# Altium schematic sheet Sheet1.SchDoc
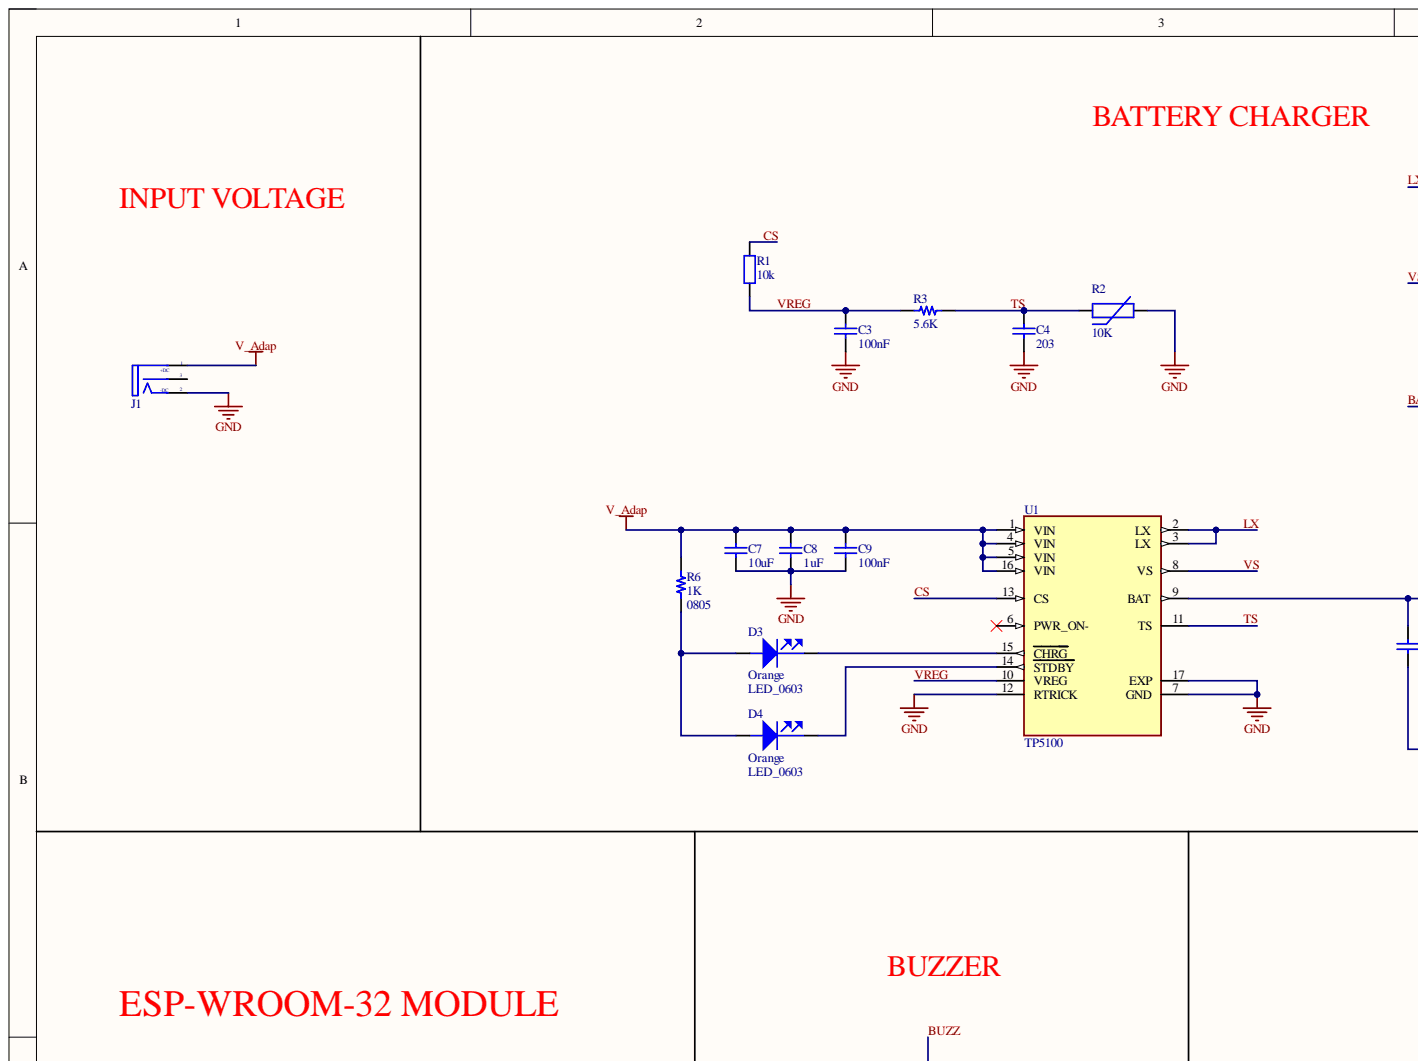
[diagram p0: Sheet1.SchDoc, top left]
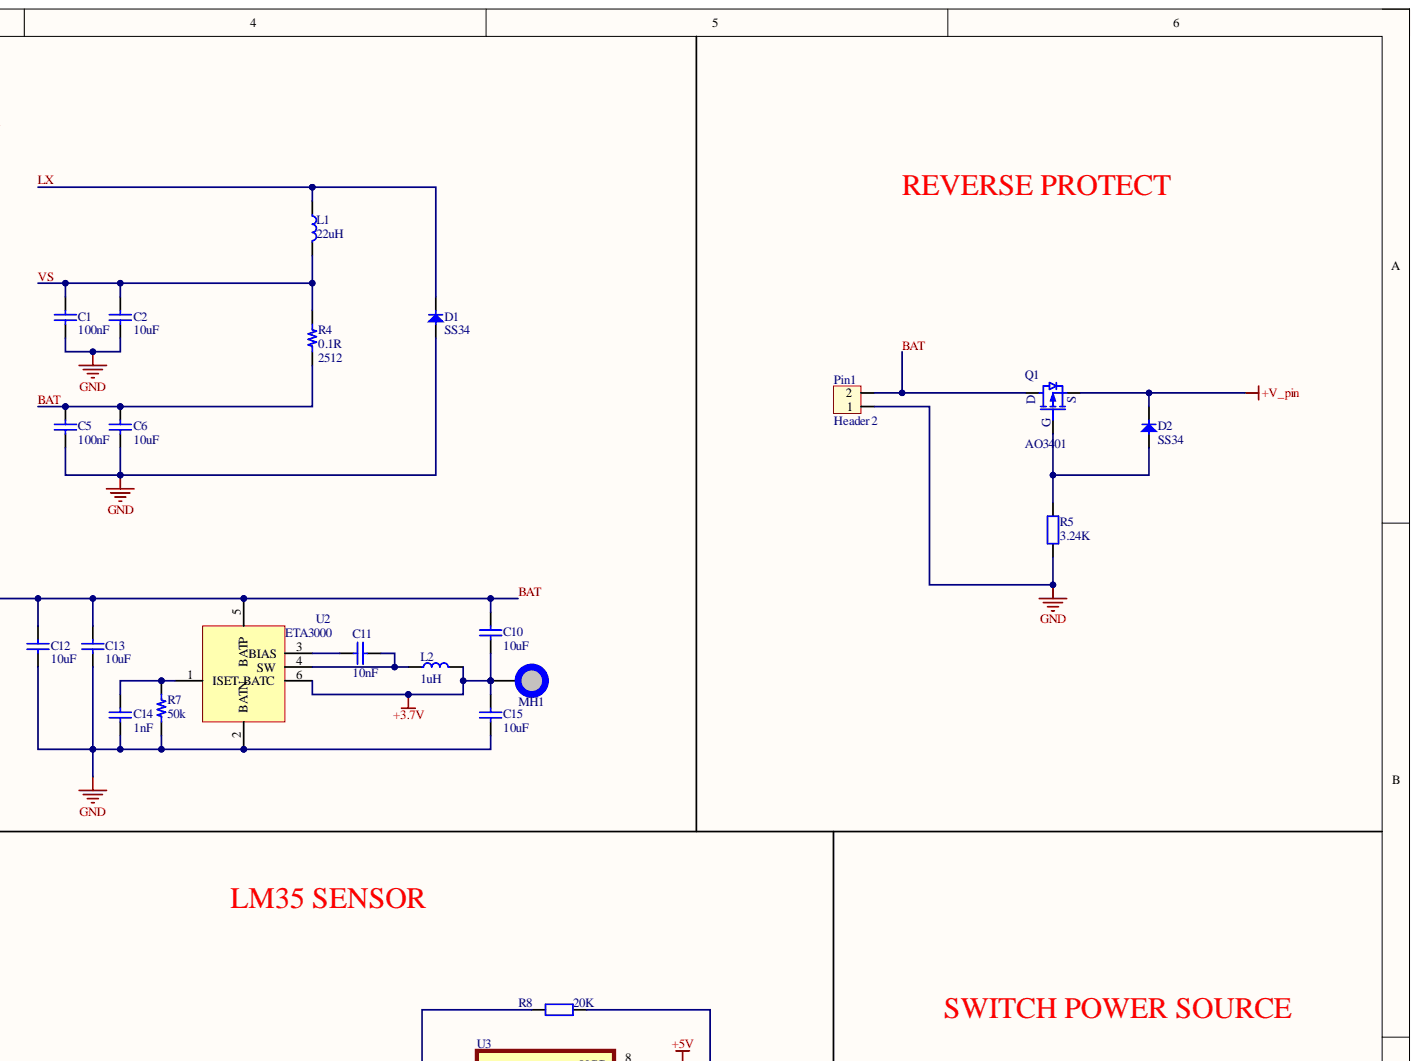
[diagram p1: Sheet1.SchDoc, top right]
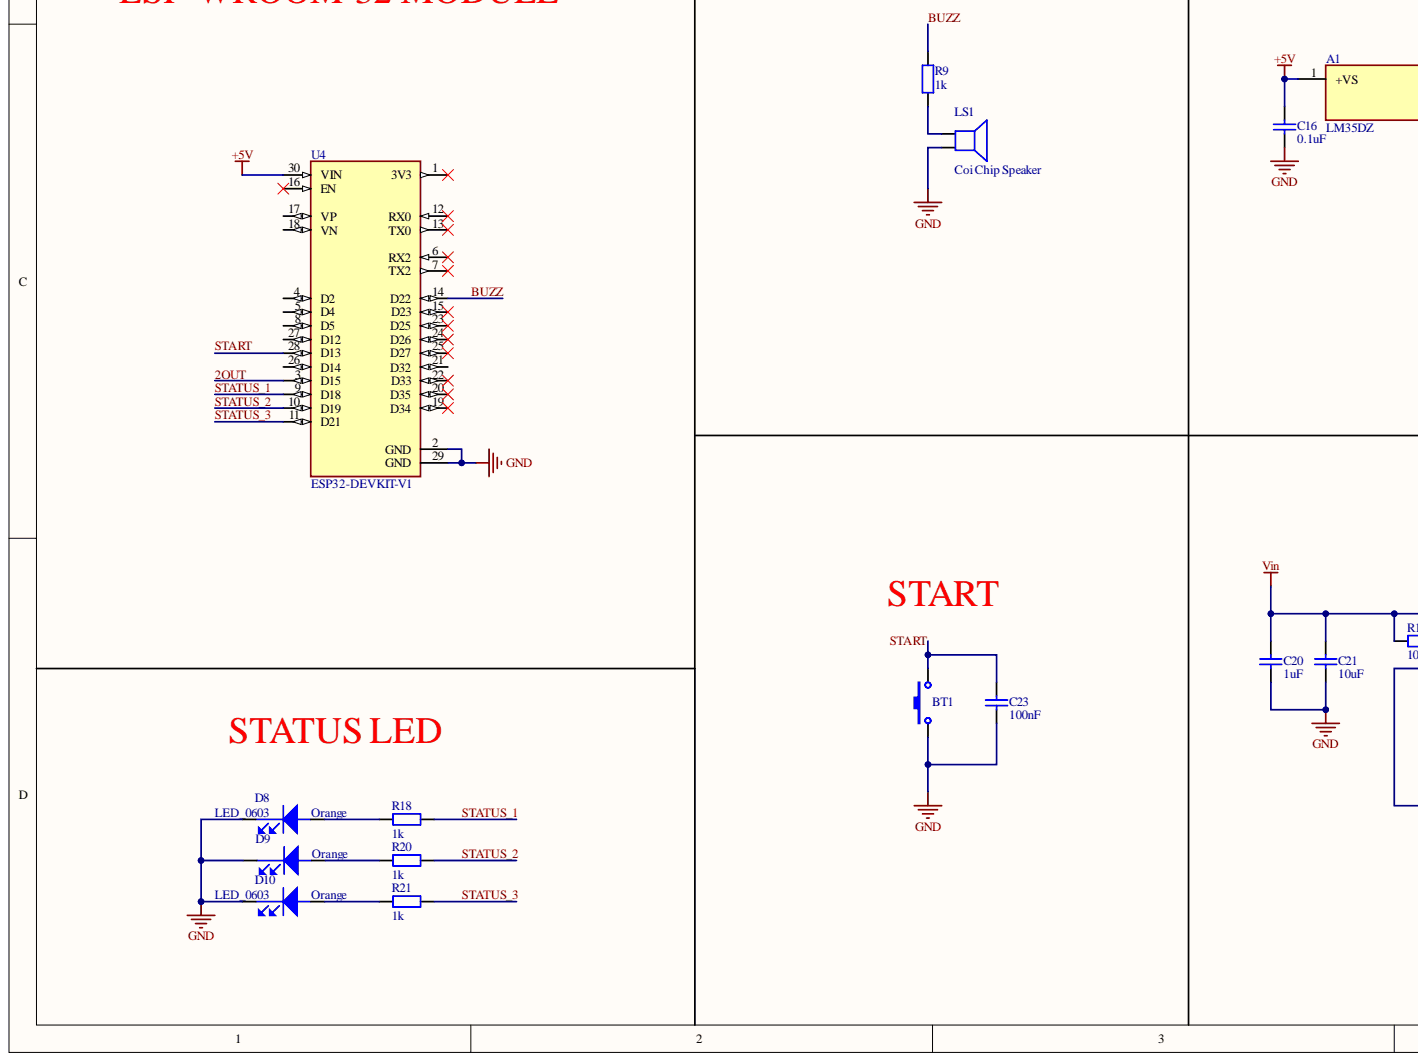
[diagram p2: Sheet1.SchDoc, bottom left]
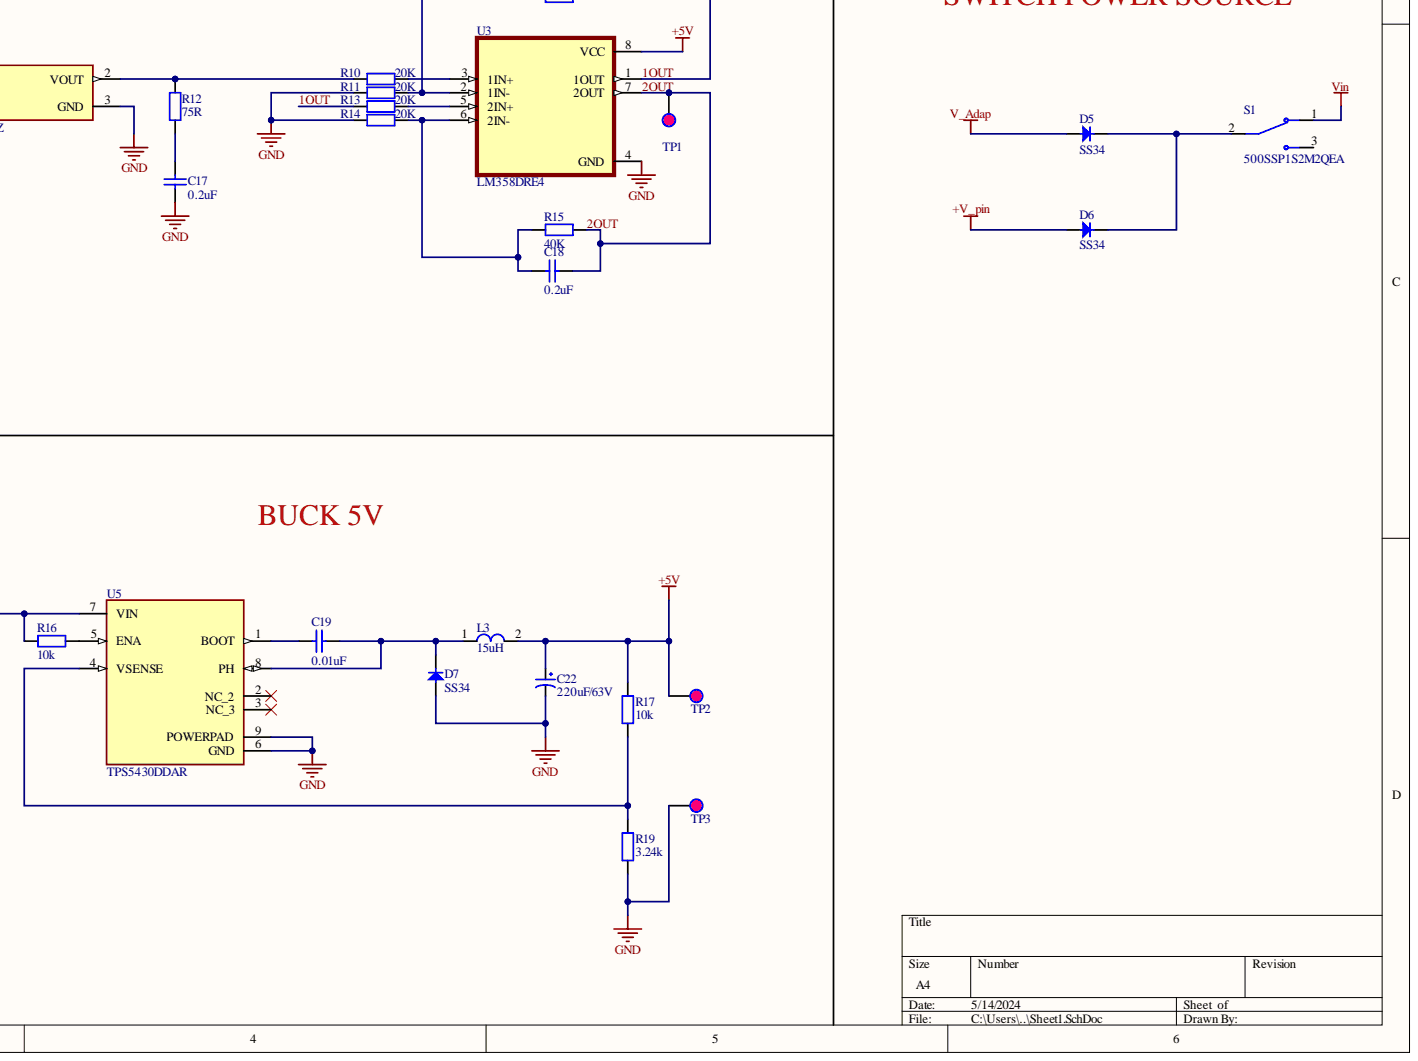
[diagram p3: Sheet1.SchDoc, bottom right]

## Parts
A1  LM35DZ  [TO92127P521H735-3]
BT1  Nut Nhan 2 Chan SMD 3x6x2.5  [Nut Nhan 2 Chan SMD 3x6x2.5]  (value not drawn)
C1  100nF  [C0603C/1608MM]
C2  10uF  [C0603C/1608MM]
C3  100nF  [C0603C/1608MM]
C4  203  [tu gom]
C5  100nF  [C0603C/1608MM]
C6  10uF  [C0603C/1608MM]
C7  10uF  [C0603C/1608MM]
C8  1uF  [C0603C/1608MM]
C9  100nF  [C0603C/1608MM]
C10  10uF  [C0603C/1608MM]
C11  10nF  [C0603C/1608MM]
C12  10uF  [C0603C/1608MM]
C13  10uF  [C0603C/1608MM]
C14  1nF  [C0603C/1608MM]
C15  10uF  [C0603C/1608MM]
C16  0.1uF  [tu 0805]
C17  0.2uF  [tu 0805]
C18  0.2uF  [tu 0805]
C19  0.01uF  [tu 0805]
C20  1uF  [tu 0805]
C21  10uF  [tu 0805]
C22  220uF/63V  [CAP_ALUM_D070_S35_H115]
C23  100nF  [C0603C/1608MM]
D1  SS34  [SMA (DO-214AC)]
D2  SS34  [SMA (DO-214AC)]
D3  Orange  Package / Case: LED_0603  [LED_0603_0m65]
D4  Orange  Package / Case: LED_0603  [LED_0603_0m65]
D5  SS34  [SMA (DO-214AC)]
D6  SS34  [SMA (DO-214AC)]
D7  SS34  [SMA (DO-214AC)]
D8  Orange  Package / Case: LED_0603  [LED_0603_0m65]
D9  Orange  [LED_0603_0m65]
D10  Orange  Package / Case: LED_0603  [LED_0603_0m65]
J1  DCIN  [DC0_SMD]  (value not drawn)
L1  22uH  [IND 22uH]
L2  1uH  [IND 22uH]
L3  15uH  [L-SMD-M-7.3x4.5]
LS1  Coi Chip Speaker  [Coi Chip Speacker]
MH1  MH_3MMD_1.5MMH  [MH_3MMD_1.5MMH]  (value not drawn)
Pin1  Header 2  [HED2]
Q1  AO3401  [SOT 23]
R1  10k  [tro smd 0603]
R2  10K  [RESC-0603 (1608)]
R3  5.6K  [R0603B/1608MM]
R4  0.1R  Case/Package: 2512  [R2512B/6332MM]
R5  3.24K  [tro smd 0805]
R6  1K  Case Code (Imperial): 0805  [R0805B/2012MM]
R7  50k  [R0603B/1608MM]
R8  20K  [tro smd 0805]
R9  1k  [tro smd 0603]
R10  20K  [tro smd 0805]
R11  20K  [tro smd 0805]
R12  75R  [tro smd 0805]
R13  20K  [tro smd 0805]
R14  20K  [tro smd 0805]
R15  40K  [tro smd 0805]
R16  10k  [tro smd 0805]
R17  10k  [tro smd 0805]
R18  1k  [tro smd 0603]
R19  3.24k  [tro smd 0805]
R20  1k  [tro smd 0603]
R21  1k  [tro smd 0603]
S1  500SSP1S2M2QEA  [SW_500SSP1S2M2QEA]
TP1  Testpoint 2  [TP - 2]  (value not drawn)
TP2  Testpoint 2  [TP - 2]  (value not drawn)
TP3  Testpoint 2  [TP - 2]  (value not drawn)
U1  TP5100  [QFN65P400X400X90-17N]
U2  ETA3000  [ETA300]
U3  LM358DRE4  [SOIC127P599X175-8N]
U4  ESP32-DEVKIT-V1  [MODULE_ESP32_DEVKIT_V1]
U5  TPS5430DDAR  [CONV_TPS5430DDAR]

## Nets
+3.7V: C10.1 C15.2 L2.2 MH1.1 U2.6(BATC)
+5V: A1.1 C16.1 C22.1 L3.2 R17.2 TP2.1 U3.8(VCC) U4.30(VIN)
+V_pin: D2.2 D6.1 Q1.2
GND: A1.3 BT1.1 C1.1 C2.1 C3.1 C4.2 C5.1 C6.1 C7.1 C8.1 C9.1 C12.1 C13.1 C14.1 C15.1 C16.2 C17.2 C20.2 C21.2 C22.2 C23.1 D1.1 D7.1 D8.2 D9.2 D10.2 J1.2 LS1.2 Pin1.1 R2.2 R5.1 R7.1 R11.2 R14.2 R19.1 TP3.1 U1.7(GND) U1.12(RTRICK) U1.17(EXP) U2.2(BATN) U3.4(GND) U4.2(GND) U4.29(GND) U5.6(GND) U5.9(POWERPAD)
V_Adap: C7.2 C8.2 C9.2 D5.1 J1.1 R6.2 U1.1(VIN) U1.4(VIN) U1.5(VIN) U1.16(VIN)
Vin: C20.1 C21.1 R16.2 S1.1 U5.7(VIN)
1OUT: R8.1 R13.2 U3.1(1OUT)
2OUT: C18.2 R15.2 TP1.1 U3.7(2OUT) U4.3(D15)
BAT: C5.2 C6.2 C10.2 C12.2 C13.2 Pin1.2 Q1.3 R4.1 U1.9(BAT) U2.5(BATP)
BUZZ: R9.2 U4.14(D22)
CS: R1.2 U1.13(CS)
LX: D1.2 L1.1 U1.2(LX) U1.3(LX)
START: BT1.2 C23.2 U4.28(D13)
STATUS_1: R18.1 U4.9(D18)
STATUS_2: R20.1 U4.10(D19)
STATUS_3: R21.1 U4.11(D21)
TS: C4.1 R2.1 R3.1 U1.11(TS)
VREG: C3.2 R1.1 R3.2 U1.10(VREG)
VS: C1.2 C2.2 L1.2 R4.2 U1.8(VS)
NetA1_2: A1.2 R10.1 R12.2
NetC11_1: C11.1 U2.3(BIAS)
NetC11_2: C11.2 L2.1 U2.4(SW)
NetC14_2: C14.2 R7.2 U2.1(ISET)
NetC17_1: C17.1 R12.1
NetC18_1: C18.1 R14.1 R15.1 U3.6(2IN-)
NetC19_1: C19.1 U5.1(BOOT)
NetC19_2: C19.2 D7.2 L3.1 U5.8(PH)
NetD2_1: D2.1 Q1.1 R5.2
NetD3_1: D3.1 D4.1 R6.1
NetD3_2: D3.2 U1.15(CHRG)
NetD4_2: D4.2 U1.14(STDBY)
NetD5_2: D5.2 D6.2 S1.2
NetD8_1: D8.1 R18.2
NetD9_1: D9.1 R20.2
NetD10_1: D10.1 R21.2
NetLS1_1: LS1.1 R9.1
NetR8_2: R8.2 R11.1 U3.2(1IN-)
NetR10_2: R10.2 U3.3(1IN+)
NetR13_1: R13.1 U3.5(2IN+)
NetR16_1: R16.1 U5.5(ENA)
NetR17_1: R17.1 R19.2 U5.4(VSENSE)

Not connected: J1 3; S1 3; U1 6(PWR_ON-); U4 1(3V3) 4(D2) 5(D4) 6(RX2) 7(TX2) 8(D5) 12(RX0) 13(TX0) 15(D23) 16(EN) 17(VP) 18(VN) 19(D34) 20(D35) 21(D32) 22(D33) 23(D25) 24(D26) 25(D27) 26(D14) 27(D12); U5 2(NC_2) 3(NC_3)

## Library data of the parts
A1 = LM35DZ/NOPB: Temperature Sensor Analog, Local 0Â°C ~ 100Â°C 10mV/Â°C TO-92-3; MF: Texas Instruments; Availability: In Stock; Check_prices: https://www.snapeda.com/parts/LM35DZ/Texas+Instruments/view-part/?ref=eda; Component Kind: Standard; Library Name: LM35DZ_NOPB.IntLib; MP: LM35DZ; Package: E-PKG AXIAL-LEADED-2 ON Semiconductor; Price: None; Purchase-URL: https://pricing.snapeda.com/search/part/LM35DZ/?ref=eda; SnapEDA_Link: https://www.snapeda.com/parts/LM35DZ/Texas+Instruments/view-part/?ref=snap
BT1 = Nut Nhan 2 Chan SMD 3x6x2.5: Ma_Hang: MH001036; Ten: Nút Nhấn 2 Chân 3x6x2.5MM SMD; Component Kind: Standard; Description: Nut Nhan 2 Chan SMD 3x6x2.5; Library Name: Altium_Lib_by_KhueNguyenCreator.IntLib
C1 C3 C5 C9 C23 = CAP CER 100nF 16V 5% X7R 0603: Cap Ceramic 0.1uF 16V X7R 5% SMD 0603 125C T/R; Manufacturer: Yageo; Manufacturer Part Number: CC0603JRX7R7BB104; Capacitance: 100nF; Case Code (Imperial): 0603; Case Code (Metric): 1608; Case/Package: 0603; Component Kind: Standard; ComponentLink1Description: https://www.verical.com/server-webapp/html/getDatasheet?partID=403543&src-supplier=Verical; ComponentLink1URL: https://www.verical.com/server-webapp/html/getDatasheet?partID=403543&src-supplier=Verical; Depth: 0.8mm; Dielectric: X7R; Halogen Free: Halogen Free; Lead Free: Lead Free; Length: 1.6mm; Max Operating Temperature: 125°C; Min Operating Temperature: -55°C; Mount: Surface Mount; Number of Pins: 2; Packaging: Tape and Reel; Pricing 1: 1=0.1, 10=0.077, 50=0.042, 100=0.0343, 500=0.02448, 1000=0.01923 (USD); Radiation Hardening: No; RoHS Compliant: Yes; Series: CC; Stock 1: 333951; Supplier 1: Digi-Key; Supplier Part Number 1: 311-1776-1-ND; Thickness: 0.035inch; Tolerance: 5%; Voltage Rating: 16V; Voltage Rating (DC): 16V; Width: 0.031inch; Library Name: Howard.SchLib
C2 C6-C8 C10 C12 C13 C15 = CAP CER 10uF 16V X7R 0603: CAP CER 0603 10uF 16V X7R 10%; Component Kind: Standard; Library Name: Howard.SchLib
C4 = Tu gom 203 50V: Cắm; Don_Vi: Con; Ma_Hang: MH007482; So_Chan: 2; Ten_Linh_Kien: Tụ gốm 203 -20nF 50V; Chung_Loai: Cắm; Component Kind: Standard; Library Name: Altium_Lib_by_KhueNguyenCreator.IntLib
C11 = CAP CER 10nF 16V X7R 0603: CAP CER 0603 10NF 16V X7R 10%; Manufacturer: KEMET; Manufacturer Part Number: C0603C103K4RECAUTO; Capacitance: 10nF; Case Code (Imperial): 0603; Case Code (Metric): 1608; Case/Package: 0603; Component Kind: Standard; ComponentLink1Description: https://api.kemet.com/component-edge/download/datasheet/C0603C103K4RECAUTO.pdf?src-supplier=Digi-Key; ComponentLink1URL: https://api.kemet.com/component-edge/download/datasheet/C0603C103K4RECAUTO.pdf?src-supplier=Digi-Key; Max Operating Temperature: 125°C; Min Operating Temperature: -55°C; Mount: Surface Mount; Packaging: Tape and Reel; Pricing 1: 1=0.15, 10=0.11, 50=0.0624, 100=0.0517, 500=0.03624, 1000=0.03 (USD); Ratings: AEC-Q200; RoHS Compliant: Yes; Stock 1: 50866; Supplier 1: Digi-Key; Supplier Part Number 1: 399-17880-1-ND; Tolerance: 10%; Voltage Rating: 16V; Library Name: Howard.SchLib
C14 = CAP CER 1nF 16V 5% X7R 0603: 1000 pF ±5% 16V Ceramic Capacitor X7R 0603 (1608 Metric); Manufacturer: KEMET; Manufacturer Part Number: C0603X102J4RECAUTO; Capacitance: 1nF; Case Code (Imperial): 0603; Case Code (Metric): 1608; Case/Package: 0603; Component Kind: Standard; ComponentLink1Description: http://www.farnell.com/datasheets/2743686.pdf?src-supplier=Newark; ComponentLink1URL: http://www.farnell.com/datasheets/2743686.pdf?src-supplier=Newark; ComponentLink2Description: http://www.farnell.com/datasheets/2743686.pdf?src-supplier=Farnell; ComponentLink2URL: http://www.farnell.com/datasheets/2743686.pdf?src-supplier=Farnell; ComponentLink3Description: https://api.kemet.com/component-edge/download/datasheet/C0603X102J4RECAUTO.pdf?src-supplier=Digi-Key; ComponentLink3URL: https://api.kemet.com/component-edge/download/datasheet/C0603X102J4RECAUTO.pdf?src-supplier=Digi-Key; Features: Soft Termination; Max Operating Temperature: 125°C; Min Operating Temperature: -55°C; Mount: Surface Mount; Packaging: Tape and Reel; Pricing 1: 1=0.22, 10=0.147, 50=0.0882, 100=0.0744, 500=0.0509, 1000=0.04503 (USD); Ratings: AEC-Q200; RoHS Compliant: Yes; Stock 1: 2638; Supplier 1: Digi-Key; Supplier Part Number 1: 399-17840-1-ND; Tolerance: 5%; Voltage Rating: 16V; Library Name: Howard.SchLib
C16-C21 = Tu 0805 10pF 50V 10%: Dán; Don_Vi: Con; Ma_Hang: MH004554; So_Chan: 2; Ten_Linh_Kien: Tụ 0805 10P 10% 50V; Chung_Loai: Dán; Component Kind: Standard; Library Name: Tu dien.SchLib
C22 = CAP ALUM 47UF 20% 63V RADIAL: Cap Aluminum 47uF 63V 20% (8 X 11.5mm) Radial 3.5mm 405mA 3000 hr 105C Ammo Pack; Manufacturer: Nichicon; Manufacturer Part Number: UPW1J470MPD1TD; Dielectric Material: Aluminium; ESR (Equivalent Series Resistance): 684mR; Impedance: 342mR; Life (Hours): 3000Hour; Capacitance: 47uF; Case/Package: Radial; Component Kind: Standard; ComponentLink1Description: https://datasheet.ciiva.com/7907/e-pw-7907438.pdf?src-supplier=Element14; ComponentLink1URL: https://datasheet.ciiva.com/7907/e-pw-7907438.pdf?src-supplier=Element14; ComponentLink2Description: https://datasheet.ciiva.com/46391/1909381-46391130.pdf?src-supplier=Element14; ComponentLink2URL: https://datasheet.ciiva.com/46391/1909381-46391130.pdf?src-supplier=Element14; ComponentLink3Description: https://datasheet.ciiva.com/29546/e-pw-29546670.pdf?src-supplier=Digi-Key; ComponentLink3URL: https://datasheet.ciiva.com/29546/e-pw-29546670.pdf?src-supplier=Digi-Key; ComponentLink4Description: https://datasheet.ciiva.com/29546/e-pw-29546669.pdf?src-supplier=Digi-Key; ComponentLink4URL: https://datasheet.ciiva.com/29546/e-pw-29546669.pdf?src-supplier=Digi-Key; ComponentLink5Description: https://datasheet.ciiva.com/16502/e-pw-16502651.pdf?src-supplier=Future+Electronics; ComponentLink5URL: https://datasheet.ciiva.com/16502/e-pw-16502651.pdf?src-supplier=Future+Electronics; Diameter: 8mm; Height: 11.5mm; Height - Seated (Max): 0.512inch; Lead Free: Lead Free; Lead Pitch: 3.5mm; Max Operating Temperature: 105°C; Min Operating Temperature: -55°C; Mount: Through Hole; Package Quantity: 1000; Packaging: Tape and Reel; Pricing 1: 1=0.45, 10=0.321, 50=0.2424, 100=0.2111, 500=0.15634 (USD); Radiation Hardening: No; Reach SVHC Compliant: Yes; Ripple Current: 405mA; RoHS Compliant: Yes; Series: UPW; Stock 1: 26935; Supplier 1: Digi-Key; Supplier Part Number 1: 493-11607-1-ND; Termination: Radial; Tolerance: 20%; Voltage Rating: 63V; Voltage Rating (DC): 63V; Library Name: Howard.SchLib
D1 D2 D5-D7 = DIODE SCHOTTKY 40V 3A SMC: SS Series 500 mV 3 A 40 V Max Reverse Voltage Schottky Rectifier - DO-214AB; Manufacturer: Taiwan Semiconductor; Current Rating: 3A; Element Configuration: Single; Max Repetitive Reverse Voltage (Vrrm): 40V; Max Reverse Current: 500uA; Max Surge Current: 100A; Polarity: Standard; Case/Package: SMC; Component Kind: Standard; ComponentLink1Description: http://datasheet.octopart.com/SS34-Taiwan-Semiconductor-datasheet-34355611.pdf?src-supplier=Digi-Key; ComponentLink1URL: http://datasheet.octopart.com/SS34-Taiwan-Semiconductor-datasheet-34355611.pdf?src-supplier=Digi-Key; ComponentLink2Description: https://datasheet.octopart.com/SS34-Taiwan-Semiconductor-datasheet-13721713.pdf?src-supplier=Farnell; ComponentLink2URL: https://datasheet.octopart.com/SS34-Taiwan-Semiconductor-datasheet-13721713.pdf?src-supplier=Farnell; ComponentLink3Description: https://datasheet.octopart.com/SS34-Taiwan-Semiconductor-datasheet-68472394.pdf?src-supplier=Element14; ComponentLink3URL: https://datasheet.octopart.com/SS34-Taiwan-Semiconductor-datasheet-68472394.pdf?src-supplier=Element14; ComponentLink4Description: https://datasheet.octopart.com/SS34-Taiwan-Semiconductor-datasheet-8823.pdf?src-supplier=Mouser; ComponentLink4URL: https://datasheet.octopart.com/SS34-Taiwan-Semiconductor-datasheet-8823.pdf?src-supplier=Mouser; ComponentLink5Description: http://datasheet.octopart.com/SS34-Taiwan-Semiconductor-datasheet-34355611.pdf?src-supplier=Avnet; ComponentLink5URL: http://datasheet.octopart.com/SS34-Taiwan-Semiconductor-datasheet-34355611.pdf?src-supplier=Avnet; ComponentLink6Description: http://datasheet.octopart.com/SS34-Taiwan-Semiconductor-datasheet-34355611.pdf?src-supplier=Onlinecomponents.com; ComponentLink6URL: http://datasheet.octopart.com/SS34-Taiwan-Semiconductor-datasheet-34355611.pdf?src-supplier=Onlinecomponents.com; Forward Current: 3A; Lead Free: Lead Free; Manufacturer Part Number: SS34; Max Operating Temperature: 125°C; Max Reverse Leakage Current: 500uA; Min Operating Temperature: -55°C; Mount: Surface Mount; Number of Pins: 2; Output Current: 3A; Package Quantity: 3000; Packaging: Tape and Reel; Peak Non-Repetitive Surge Current: 100A; Pricing 1: 3000=0.20021, 6000=0.18729, 15000=0.1863 (USD); Reach SVHC Compliant: Yes; RoHS Compliant: Yes; Stock 1: 0; Supplier 1: Digi-Key; Supplier Part Number 1: 1801-SS34TR-ND; Voltage Rating (DC): 40V; Library Name: Howard.SchLib
D3 D4 D8-D10 = LED ORANGE 606NM 0603: LED SMARTLED ORANGE 606NM 0603; Manufacturer: OSRAM Opto Semiconductors Inc; Manufacturer Part Number: LO L29K-H2K1-24-Z; By: Hieu.Nguyen_20191030; Current - Test: 2mA; Lens Style/Size: Rectangle with Flat Top, 1.3mm x 0.8mm; Lens Type: Diffused; Luminous Flux @ Current - Test: 25 mlm; Millicandela Rating: 6.3mcd; Viewing Angle: 160°; Voltage - Forward (Vf) Typ: 1.8V; Wavelength - Peak: 610nm; Category: Optoelectronics; Color: Orange; Component Kind: Standard; ComponentLink1Description: http://catalog.osram-os.com/catalogue/catalogue.do;jsessionid=302CF83AF637AE24E40AEB0ADA267EDD?act=downloadFile&favOid=020000010003f9de000100b6; ComponentLink1URL: http://catalog.osram-os.com/catalogue/catalogue.do;jsessionid=302CF83AF637AE24E40AEB0ADA267EDD?act=downloadFile&favOid=020000010003f9de000100b6; Height: 0.65mm; Mounting Type: Surface Mount; Packaging: Cut Tape (CT); Pricing 1: 1=0.17, 10=0.141, 100=0.1127, 250=0.0986, 500=0.09016 (USD); RoHS: RoHS Compliant; Size / Dimension: 1.70mm L x 0.80mm W; Stock 1: 15900; Supplier 1: Digi-Key; Supplier Part Number 1: 475-2740-1-ND; Wavelength - Dominant: 606nm; Library Name: Howard.SchLib
J1 = DCIN: CONN POWER JACK 2.1X5.5MM SMD; Component Kind: Standard; Library Name: Howard.SchLib
L1 L2 = IND FIXED 22UH 3A 50 MOHM SMD CD104-220 10x10x4mm: Inductor Power Shielded Wirewound 22uH 20% 100KHz Ferrite 3A 0.05Ohm DCR Automotive T/R; Manufacturer: Wurth Electronics; Manufacturer Part Number: 7447714220; DC Resistance (DCR): 50mR; Saturation Current: 3.6A; Self Resonant Frequency: 14.5MHz; Case/Package: Nonstandard; Component Kind: Standard; ComponentLink1Description: https://datasheet.ciiva.com/13320/7447714220-70113-13320863.pdf?src-supplier=Mouser; ComponentLink1URL: https://datasheet.ciiva.com/13320/7447714220-70113-13320863.pdf?src-supplier=Mouser; ComponentLink2Description: https://datasheet.ciiva.com/1058/7447714220-1058608.pdf?src-supplier=Digi-Key; ComponentLink2URL: https://datasheet.ciiva.com/1058/7447714220-1058608.pdf?src-supplier=Digi-Key; ComponentLink3Description: https://datasheet.ciiva.com/30489/0900766b81281c0b-30489748.pdf?src-supplier=Rs; ComponentLink3URL: https://datasheet.ciiva.com/30489/0900766b81281c0b-30489748.pdf?src-supplier=Rs; ComponentLink4Description: https://datasheet.ciiva.com/7691/1736273-7691674.pdf?src-supplier=Element14; ComponentLink4URL: https://datasheet.ciiva.com/7691/1736273-7691674.pdf?src-supplier=Element14; ComponentLink5Description: https://datasheet.ciiva.com/1058/7447714220-1058609.pdf?src-supplier=Digi-Key; ComponentLink5URL: https://datasheet.ciiva.com/1058/7447714220-1058609.pdf?src-supplier=Digi-Key; ComponentLink6Description: https://datasheet.ciiva.com/48691/001087798-da-01-ml-we-pd-smd-speicherdrossel-74477142-de-en-48691509.pdf?src-supplier=Conrad; ComponentLink6URL: https://datasheet.ciiva.com/48691/001087798-da-01-ml-we-pd-smd-speicherdrossel-74477142-de-en-48691509.pdf?src-supplier=Conrad; Core Material: Ferrite; Current Rating: 3A; Depth: 10mm; Height: 5mm; Height - Seated (Max): 0.197inch; Inductance: 22uH; Inductance Tolerance: 20%; Length: 10mm; Max DC Current: 3A; Max Operating Temperature: 125°C; Min Operating Temperature: -40°C; Mount: Surface Mount; Package Quantity: 1; Packaging: Tape and Reel; Pricing 1: 1=2.26, 10=2.172, 50=1.961, 100=1.759, 250=1.61 (USD); Ratings: AEC-Q200; Resistance: 50mR; Resistor Type: Wirewound; RMS Current (Irms): 3A; RoHS Compliant: Yes; Series: WE-PD; Series Resistance: 50mR; Shielding: Shielded; Stock 1: 9408; Supplier 1: Digi-Key; Supplier Part Number 1: 732-2992-1-ND; Termination: SMD/SMT; Test Frequency: 100kHz; Tolerance: 20%; Width: 10mm; Library Name: Howard.SchLib
L3 = L-SMD-1: Manufacturer: Wurth Elektronik; partNo: 78477010; Inductance: 1.0 μH; Component Kind: Standard; ComponentLink1Description: Manufacturer; ComponentLink1URL: http://www.we-online.de; ComponentLink2Description: Datasheet; ComponentLink2URL: http://katalog.we-online.de/pbs/datasheet/78477010.pdf; Created Date: Nov.2015; Library Name: My Library.SchLib; Created by: Baojun Chen
LS1 = Coi Chip Speaker: Còi chip; Component Kind: Standard; Library Name: Altium_Lib_by_KhueNguyenCreator.IntLib
MH1 = MH_3MMD_1.5MMH: Mounting Hole 3mm Diameter, 1.5mm Hole; Component Kind: Standard; Library Name: Howard.SchLib
Pin1 = Hed2: Header 2 (Green); Component Kind: Standard; Library Name: My Library.SchLib; Value: Header 2
Q1 = MOSFET P-CH 30V 4A SOT23-3: Transistor P-Channel MOSFET; 30V; 4, 2A; 1, 4W; 85 mOhm; -55°C ~ 150°C; ;; Manufacturer: Alpha & Omega Semiconductor; Manufacturer Part Number: AO3401A; Continuous Drain Current (ID): 4A; Input Capacitance: 1.2nF; Max Power Dissipation: 1.4W; Number of Elements: 1; Rds On Max: 44mR; Case/Package: TO-236-3; Component Kind: Standard; ComponentLink1Description: https://datasheet.ciiva.com/940/ao3401a-940720.pdf?src-supplier=Digi-Key; ComponentLink1URL: https://datasheet.ciiva.com/940/ao3401a-940720.pdf?src-supplier=Digi-Key; ComponentLink2Description: https://datasheet.ciiva.com/26927/getdatasheetpartid-79048-26927888.pdf?src-supplier=Verical; ComponentLink2URL: https://datasheet.ciiva.com/26927/getdatasheetpartid-79048-26927888.pdf?src-supplier=Verical; Drain to Source Voltage (Vdss): 30V; Lead Free: Lead Free; Max Operating Temperature: 150°C; Min Operating Temperature: -55°C; Mount: Surface Mount; Number of Pins: 3; Packaging: Cut Tape; Power Dissipation: 1.4W; Pricing 1: 1=0.44, 10=0.333, 100=0.2073, 500=0.1418, 1000=0.10908 (USD); Radiation Hardening: No; RoHS Compliant: Yes; Series: -; Stock 1: 1131740; Supplier 1: Digi-Key; Supplier Part Number 1: 785-1001-1-ND; Library Name: Howard.SchLib
R1 = Tro 0603 5% 10k: Dán; Don_Vi: Con; Ma_Hang: MH006316; So_Chan: 2; Ten_Linh_Kien: Trở 0603 5% 10k; Chung_Loai: Dán; Component Kind: Standard; Library Name: Altium_Lib_by_KhueNguyenCreator.IntLib
R2 = NTCG163JF103FT1: THERMISTOR 10K OHM 1% 0603; CompanyID: VT-01145; Solder: SMT; Category: RESISTOR; Component Kind: Standard; Library Name: BYTECH_HW_LIB_2019.IntLib; MPN: NTCG163JF103FT1; Value: 10K
R3 R7 = RES SMD 10K OHM 5% 1/10W 0603: Surface Mount Thick Film Resistor, RC Series, 10 kohm, 100 mW, - 5%, 50 V, 0603 [1608 Metric]; Manufacturer: Yageo; Manufacturer Part Number: RC0603JR-0710KL; Max Power Dissipation: 100mW; Resistance: 10kR; Temperature Coefficient: 100ppm/°C; Voltage Rating: 50V; Case Code (Imperial): 0603; Case Code (Metric): 1608; Case/Package: 0603; Component Kind: Standard; ComponentLink1Description: http://www.yageo.com/documents/recent/PYu-RC_Group_51_RoHS_L_10.pdf?src-supplier=Digi-Key; ComponentLink1URL: http://www.yageo.com/documents/recent/PYu-RC_Group_51_RoHS_L_10.pdf?src-supplier=Digi-Key; Contact Plating: Tin,Matte; Depth: 0.8mm; Features: Moisture Resistant; Height - Seated (Max): 0.022inch; Lead Free: Lead Free; Length: 0.063inch; Max Operating Temperature: 155°C; Military Standard: Not; Min Operating Temperature: -55°C; Number of Pins: 2; Number of Terminations: 2; Packaging: Tape and Reel; Power Rating: 100mW; Pricing 1: 1=0.1, 10=0.02, 100=0.008, 1000=0.0036, 2500=0.00312 (USD); Radiation Hardening: No; REACH SVHC: Unknown; Resistor Type: Thick Film; RoHS Compliant: Yes; Stock 1: 10996894; Supplier 1: Digi-Key; Supplier Part Number 1: 311-10KGRCT-ND; Termination: SMD/SMT; Tolerance: 5%; Voltage Rating (DC): 50V; Width: 0.031inch; Library Name: Howard.SchLib
R4 = RES SMD 0.2 OHM 5% 1W 2512: Surface Mount Thick Film Resistor, RC Series, 10 kohm, 1W, - 5%, 50 V, 2512; Manufacturer: Yageo; Manufacturer Part Number: RC0603JR-0710KL; Power Rating: 100mW; Resistance: 10kR; Temperature Coefficient: 100ppm/°C; Voltage Rating: 50V; Case Code (Imperial): 6332; Case Code (Metric): 6332; Component Kind: Standard; ComponentLink1Description: http://www.yageo.com/documents/recent/PYu-RC_Group_51_RoHS_L_10.pdf?src-supplier=Digi-Key; ComponentLink1URL: http://www.yageo.com/documents/recent/PYu-RC_Group_51_RoHS_L_10.pdf?src-supplier=Digi-Key; Contact Plating: Tin,Matte; Depth: 0.8mm; Features: Moisture Resistant; Height - Seated (Max): 0.022inch; Lead Free: Lead Free; Length: 0.063inch; Max Operating Temperature: 155°C; Max Power Dissipation: 1W; Military Standard: Not; Min Operating Temperature: -55°C; Number of Pins: 2; Number of Terminations: 2; Packaging: Tape and Reel; Pricing 1: 1=0.1, 10=0.02, 100=0.008, 1000=0.0036, 2500=0.00312 (USD); Radiation Hardening: No; REACH SVHC: Unknown; Resistor Type: Thick Film; RoHS Compliant: Yes; Stock 1: 10996894; Supplier 1: Digi-Key; Supplier Part Number 1: 311-10KGRCT-ND; Termination: SMD/SMT; Tolerance: 5%; Voltage Rating (DC): 50V; Width: 0.031inch; Library Name: Howard.SchLib
R5 = Tro 0805 5% 560R: Dán; Don_Vi: Con; Ma_Hang: MH005261; So_Chan: 2; Ten_Linh_Kien: Trở 0805 5% 560R; Chung_Loai: Dán; Component Kind: Standard; Library Name: Dien Tro.SchLib
R6 = RES SMD 1.8M OHM 5% 1/8W 0805: Res Thick Film 0805 1.8M Ohm 5% 1/8W ±100ppm/°C Molded SMD SMD Paper T/R; Manufacturer: Yageo; Manufacturer Part Number: RC0805JR-071M8L; Max Power Dissipation: 125mW; Resistance: 1.8MR; Voltage Rating: 150V; Case Code (Metric): 2012; Case/Package: 0805; Component Kind: Standard; ComponentLink1Description: http://www.yageo.com/documents/recent/PYu-RC_Group_51_RoHS_L_9.pdf?src-supplier=Digi-Key; ComponentLink1URL: http://www.yageo.com/documents/recent/PYu-RC_Group_51_RoHS_L_9.pdf?src-supplier=Digi-Key; ComponentLink2Description: https://www.yageo.com/upload/media/product/productsearch/datasheet/rchip/PYu-RC_Group_51_RoHS_L_10.pdf?src-supplier=Digi-Key; ComponentLink2URL: https://www.yageo.com/upload/media/product/productsearch/datasheet/rchip/PYu-RC_Group_51_RoHS_L_10.pdf?src-supplier=Digi-Key; Construction: Rectangular; Contact Plating: Tin,Matte; Depth: 1.25mm; Features: Moisture Resistant; Height: 0.5mm; Lead Free: Lead Free; Length: 2mm; Max Operating Temperature: 155°C; Military Standard: Not; Min Operating Temperature: -55°C; Number of Terminations: 2; Package Quantity: 1; Packaging: Tape and Reel; Power Rating: 125mW; Pricing 1: 1=0.1, 10=0.036, 100=0.0145, 1000=0.00652, 2500=0.00566 (USD); Radiation Hardening: No; Resistor Type: Thick Film; RoHS Compliant: Yes; Series: RC; Size Code: 0805; Stock 1: 11875; Supplier 1: Digi-Key; Supplier Part Number 1: 311-1.8MARDKR-ND; Temperature Coefficient: 100ppm/°C; Termination: SMD/SMT; Tolerance: 5%; Voltage Rating (DC): 150V; Width: 1.25mm; Library Name: Howard.SchLib
R8 R10-R15 R19 = Tro 0805 5% 3.3k: Dán; Don_Vi: Con; Ma_Hang: MH005305; So_Chan: 2; Ten_Linh_Kien: Trở 0805 5% 3.3k; Chung_Loai: Dán; Component Kind: Standard; Library Name: Dien Tro.SchLib
R9 R18 R20 R21 = Tro 0603 1% 1k: Dán; Don_Vi: Con; Ma_Hang: MH006334; So_Chan: 2; Ten_Linh_Kien: Trở 0603 1% 1k; Chung_Loai: Dán; Component Kind: Standard; Library Name: Altium_Lib_by_KhueNguyenCreator.IntLib
R16 R17 = Tro 0805 5% 10k: Dán; Don_Vi: Con; Ma_Hang: MH005239; So_Chan: 2; Ten_Linh_Kien: Trở 0805 5% 10k; Chung_Loai: Dán; Component Kind: Standard; Library Name: Dien Tro.SchLib
S1 = 500SSP1S2M2QEA: Slide Switch SPDT Through Hole; Availability: Unavailable; Component Kind: Standard; MP: 500SSP1S2M2QEA; Package: None; Price: None; Library Name: Howard.SchLib
U1 = TP5100: 2A-switch buck 8.4V / 4.2V rechargeable lithium battery; MF: NanJing Top Power ASIC Corp.; Availability: Not in stock; Check_prices: https://www.snapeda.com/parts/TP5100/NanJing+Top+Power+ASIC+Corp./view-part/?ref=eda; Component Kind: Standard; MP: TP5100; Package: Package; Price: None; SnapEDA_Link: https://www.snapeda.com/parts/TP5100/NanJing+Top+Power+ASIC+Corp./view-part/?ref=snap; Library Name: PowerspIOT_1.SCHLIB
U3 = LM358DRE4: Dual standard operational amplifier 8-SOIC 0 to 70; MF: Texas Instruments; Availability: Good; Check_prices: https://www.snapeda.com/parts/LM358DRE4/Texas%20Instruments/view-part/544216/?ref=eda; Component Kind: Standard; Library Name: LM358DRE4.IntLib; MP: LM358DRE4; Package: SOIC-8 Texas Instruments; Price: None; Purchase-URL: https://pricing.snapeda.com/search/part/LM358DRE4/?ref=eda; SnapEDA_Link: https://www.snapeda.com/parts/LM358DRE4/Texas%20Instruments/view-part/544216/?ref=snap
U4 = ESP32-DEVKIT-V1: Dual core, Wi-Fi: 2.4 GHz up to 150 Mbits/s,BLE (Bluetooth Low Energy) and legacy Bluetooth, 32 bits, Up to 240 MHz; MF: Do it; Availability: Not in stock; Check_prices: https://www.snapeda.com/parts/ESP32-DEVKIT-V1/Do+it/view-part/?ref=eda; Component Kind: Standard; Library Name: ESP32-DEVKIT-V1.IntLib; MP: ESP32-DEVKIT-V1; Package: None; Price: None; SnapEDA_Link: https://www.snapeda.com/parts/ESP32-DEVKIT-V1/Do+it/view-part/?ref=snap
U5 = TPS5430DDAR: 5.5V to 36V Input, 3A, 500kHz Step-Down Converter; MF: Texas Instruments; Availability: In Stock; Check_prices: https://www.snapeda.com/parts/TPS5430DDAR/Texas+Instruments/view-part/?ref=eda; Component Kind: Standard; Library Name: TPS5430DDAR (1).IntLib; MP: TPS5430DDAR; Package: HSOIC-8 Texas Instruments; Price: None; Purchase-URL: https://pricing.snapeda.com/search/part/TPS5430DDAR/?ref=eda; SnapEDA_Link: https://www.snapeda.com/parts/TPS5430DDAR/Texas+Instruments/view-part/?ref=snap
TP1-TP3 = Testpoint 2: Component Kind: Standard; Library Name: My Library.SchLib
U2 = ETA3000: Component Kind: Standard; Library Name: PowerspIOT.SCHLIB
